# Altium schematic sheet Main Connectors.SchDoc(Designator)
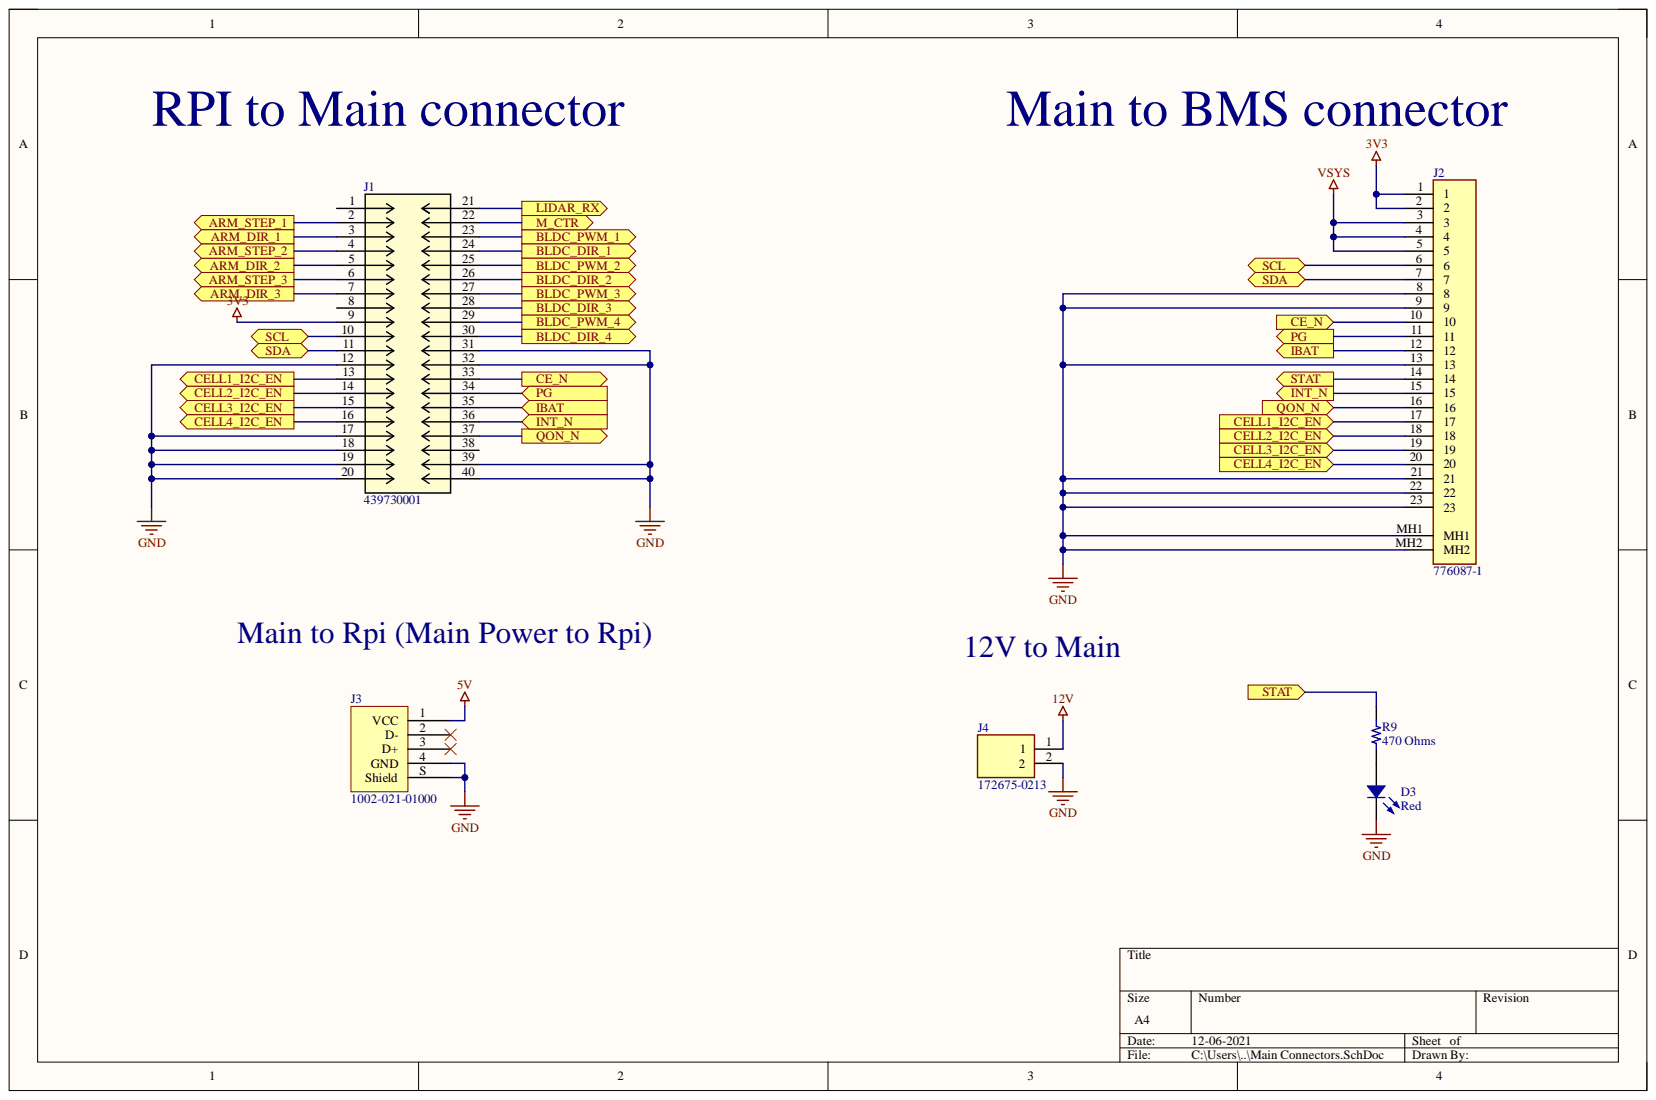
[diagram p0: Main Connectors.SchDoc]

## Parts
D3  Red  [LED 0805_2012 RED]
J1  439730001  [CON_439730001]
J2  776087-1  [7760871]
J3  1002-021-01000  [CNC TECH 1002-021-01000]
J4  172675-0213  [1726750213]
R9  470 Ohms  [RES 0603_1608]

## Ports
ARM_DIR_1, ARM_DIR_2, ARM_DIR_3, ARM_STEP_1, ARM_STEP_2, ARM_STEP_3, BLDC_DIR_1, BLDC_DIR_2, BLDC_DIR_3, BLDC_DIR_4, BLDC_PWM_1, BLDC_PWM_2, BLDC_PWM_3, BLDC_PWM_4, CE_N, CELL1_I2C_EN, CELL2_I2C_EN, CELL3_I2C_EN, CELL4_I2C_EN, IBAT, INT_N, LIDAR_RX, M_CTR, PG, QON_N, SCL, SDA, STAT

## Nets
3V3: J1.9 J2.1 J2.2
5V: J3.1(VCC)
12V: J4.1
GND: D3.2 J1.12 J1.17 J1.18 J1.19 J1.20 J1.31 J1.32 J1.39 J1.40 J2.8 J2.9 J2.13 J2.21 J2.22 J2.23 J2.MH1 J2.MH2 J3.4(GND) J3.S(Shield) J4.2
VSYS: J2.3 J2.4 J2.5
ARM_DIR_1: J1.3 ; port ARM_DIR_1
ARM_DIR_2: J1.5 ; port ARM_DIR_2
ARM_DIR_3: J1.7 ; port ARM_DIR_3
ARM_STEP_1: J1.2 ; port ARM_STEP_1
ARM_STEP_2: J1.4 ; port ARM_STEP_2
ARM_STEP_3: J1.6 ; port ARM_STEP_3
BLDC_DIR_1: J1.24 ; port BLDC_DIR_1
BLDC_DIR_2: J1.26 ; port BLDC_DIR_2
BLDC_DIR_3: J1.28 ; port BLDC_DIR_3
BLDC_DIR_4: J1.30 ; port BLDC_DIR_4
BLDC_PWM_1: J1.23 ; port BLDC_PWM_1
BLDC_PWM_2: J1.25 ; port BLDC_PWM_2
BLDC_PWM_3: J1.27 ; port BLDC_PWM_3
BLDC_PWM_4: J1.29 ; port BLDC_PWM_4
CE_N: J1.33 J2.10 ; port CE_N
CELL1_I2C_EN: J1.13 J2.17 ; port CELL1_I2C_EN
CELL2_I2C_EN: J1.14 J2.18 ; port CELL2_I2C_EN
CELL3_I2C_EN: J1.15 J2.19 ; port CELL3_I2C_EN
CELL4_I2C_EN: J1.16 J2.20 ; port CELL4_I2C_EN
IBAT: J1.35 J2.12 ; port IBAT
INT_N: J1.36 J2.15 ; port INT_N
LIDAR_RX: J1.21 ; port LIDAR_RX
M_CTR: J1.22 ; port M_CTR
PG: J1.34 J2.11 ; port PG
QON_N: J1.37 J2.16 ; port QON_N
SCL: J1.10 J2.6 ; port SCL
SDA: J1.11 J2.7 ; port SDA
STAT: J2.14 R9.2 ; port STAT
NetD3_1: D3.1 R9.1

Not connected: J1 1 8 38; J3 2(D-) 3(D+)

## Library data of the parts
D3 = CMD17-21VRD/TR8: LED RED DIFFUSED 0805 SMD; Manufacturer: Visual Communications Company - VCC; Configuration: Standard; Current - Test: 20mA; Database Table Name: LED - Indication; Lens Size: 1.40mm x 1.25mm; Lens Style: Rectangle with Flat Top; Millicandela Rating: 6mcd; Viewing Angle: 140°; Voltage - Forward (Vf) (Typ): 2V; Wavelength - Peak: 640nm; Color: Red; Component Kind: Standard; ComponentLink1Description: Datasheet; ComponentLink1URL: https://vcclite.com/wp-content/uploads/wpallimport/files/files/CMD1721Seriesver3.pdf; ComponentLink2Description: DigiKey Link; ComponentLink2URL: http://digikey.com/product-detail/en/visual-communications-company-vcc/CMD17-21VRD-TR8/L62501CT-ND/254934; Device Package: 0805 (2012 Metric); Height (Max): 1.20mm; LastUpdated: 2020-09-02 1:21:00 AM; Lens Color: Red; Lens Transparency: Diffused; Library Name: Celestial Altium Library - altium_library.DbLib; Lifecycle Status: Active; Minimum Order: 1; Mounting Type: Surface Mount; Package / Case: 0805 (2012 Metric); Packaging: TapeAndReel; Part Number: CMD17-21VRD/TR8; Part Status: Active; PartId: 366; Price: 0.12; Series: CMD17-21; Size / Dimension: 2.00mm L x 1.25mm W; Supplier 1: DigiKey; Supplier Device Package: 0805; Supplier Part Number 1: L62501CT-ND; Value: Red
J1 = 439730001: No Description Available; Mfr_Name: Molex Connector Corporation; Component Kind: Standard; Library Name: 439730001.SchLib; Manufacturer_Part_Number: 439730001; RefDes: RefDes; Type: DEV; Copyright: Copyright (C) 2021 Ultra Librarian. All rights reserved.
J2 = 776087-1: Connector; Manufacturer_Name: TE Connectivity; Arrow Part Number: 776087-1; Arrow Price/Stock: https://www.arrow.com/en/products/776087-1/te-connectivity?region=europe; Component Kind: Standard; Datasheet Link: https://componentsearchengine.com/Datasheets/1/776087-1.pdf; Height: 32.1mm; Library Name: SamacSys.SchLib; Manufacturer_Part_Number: 776087-1; Mouser Part Number: 571-776087-1; Mouser Price/Stock: https://www.mouser.co.uk/ProductDetail/TE-Connectivity/776087-1?qs=qXO2HHLAcoIjggUTPuCD9w%3D%3D
J3 = 1002-021-01000: CONN RCPT USB2.0 TYPEA 4POS VERT; Manufacturer: CNC Tech; Connector Type: USB - A; Current Rating (Amps): 1.5A; Database Table Name: Connectors - USB DVI HDMI; Gender: Receptacle; Mating Cycles: 1500; Number of Contacts: 4; Number of Ports: 1; Voltage - Rated: 30V; Component Kind: Standard; ComponentLink1Description: Datasheet; ComponentLink1URL: http://www.cnctech.us/pdfs/1002-021-01000.pdf; ComponentLink2Description: DigiKey Link; ComponentLink2URL: http://digikey.com/product-detail/en/cnc-tech/1002-021-01000/1175-1265-ND/3466946; Features: Board Lock; LastUpdated: 2020-04-30 12:00:08 PM; Library Name: Celestial Altium Library - altium_library.DbLib; Lifecycle Status: Active; Minimum Order: 1; Mounting Feature: Vertical; Mounting Type: Through Hole; Operating Temperature: -55°C ~ 85°C; Packaging: Tray; Part Number: 1002-021-01000; Part Status: Active; Price: 1.02; Series: -; Specifications: USB 2.0; Supplier 1: DigiKey; Supplier Part Number 1: 1175-1265-ND; Termination: Solder
J4 = 172675-0213: Connector; Manufacturer_Name: Molex; Component Kind: Standard; Datasheet Link: https://componentsearchengine.com/Datasheets/1/172675-0213.pdf; Height: 12.8mm; Manufacturer_Part_Number: 172675-0213; Mouser Part Number: 538-172675-0213; Mouser Price/Stock: https://www.mouser.co.uk/ProductDetail/Molex/172675-0213?qs=c8NFF48pVsBqRLFxNEkKVw%3D%3D
R9 = ERJ-3EKF4700V: RES SMD 470 OHM 1% 1/10W 0603; Manufacturer: Panasonic Electronic Components; Database Table Name: Resistors - Surface Mount - 0603; Power (Watts): 0.1W, 1/10W; Temperature Coefficient: ±100ppm/°C; Component Kind: Standard; ComponentLink1Description: Datasheet; ComponentLink1URL: https://industrial.panasonic.com/cdbs/www-data/pdf/RDA0000/AOA0000C304.pdf; ComponentLink2Description: DigiKey Link; ComponentLink2URL: http://digikey.com/product-detail/en/panasonic-electronic-components/ERJ-3EKF4700V/P470HCT-ND/1746781; Composition: Thick Film; Device Package: 0603 (1608 Metric); Features: Automotive AEC-Q200; Height - Seated (Max): 0.022" (0.55mm); LastUpdated: 2020-09-02 1:10:23 AM; Library Name: Celestial Altium Library - altium_library.DbLib; Lifecycle Status: Active; Minimum Order: 1; Number of Terminations: 2; Operating Temperature: -55°C ~ 155°C; Package / Case: 0603 (1608 Metric); Packaging: TapeAndReel; Part Number: ERJ-3EKF4700V; Part Status: Active; PartId: 5664; Price: 0.01; Ratings: AEC-Q200; Resistance: 470 Ohms; Series: ERJ; Size / Dimension: 0.063" L x 0.031" W (1.60mm x 0.80mm); Supplier 1: DigiKey; Supplier Device Package: 0603; Supplier Part Number 1: P470HCT-ND; Tolerance: ±1%; Value: 470 Ohms
